# Altium schematic sheet DVD_adapter.SchDoc
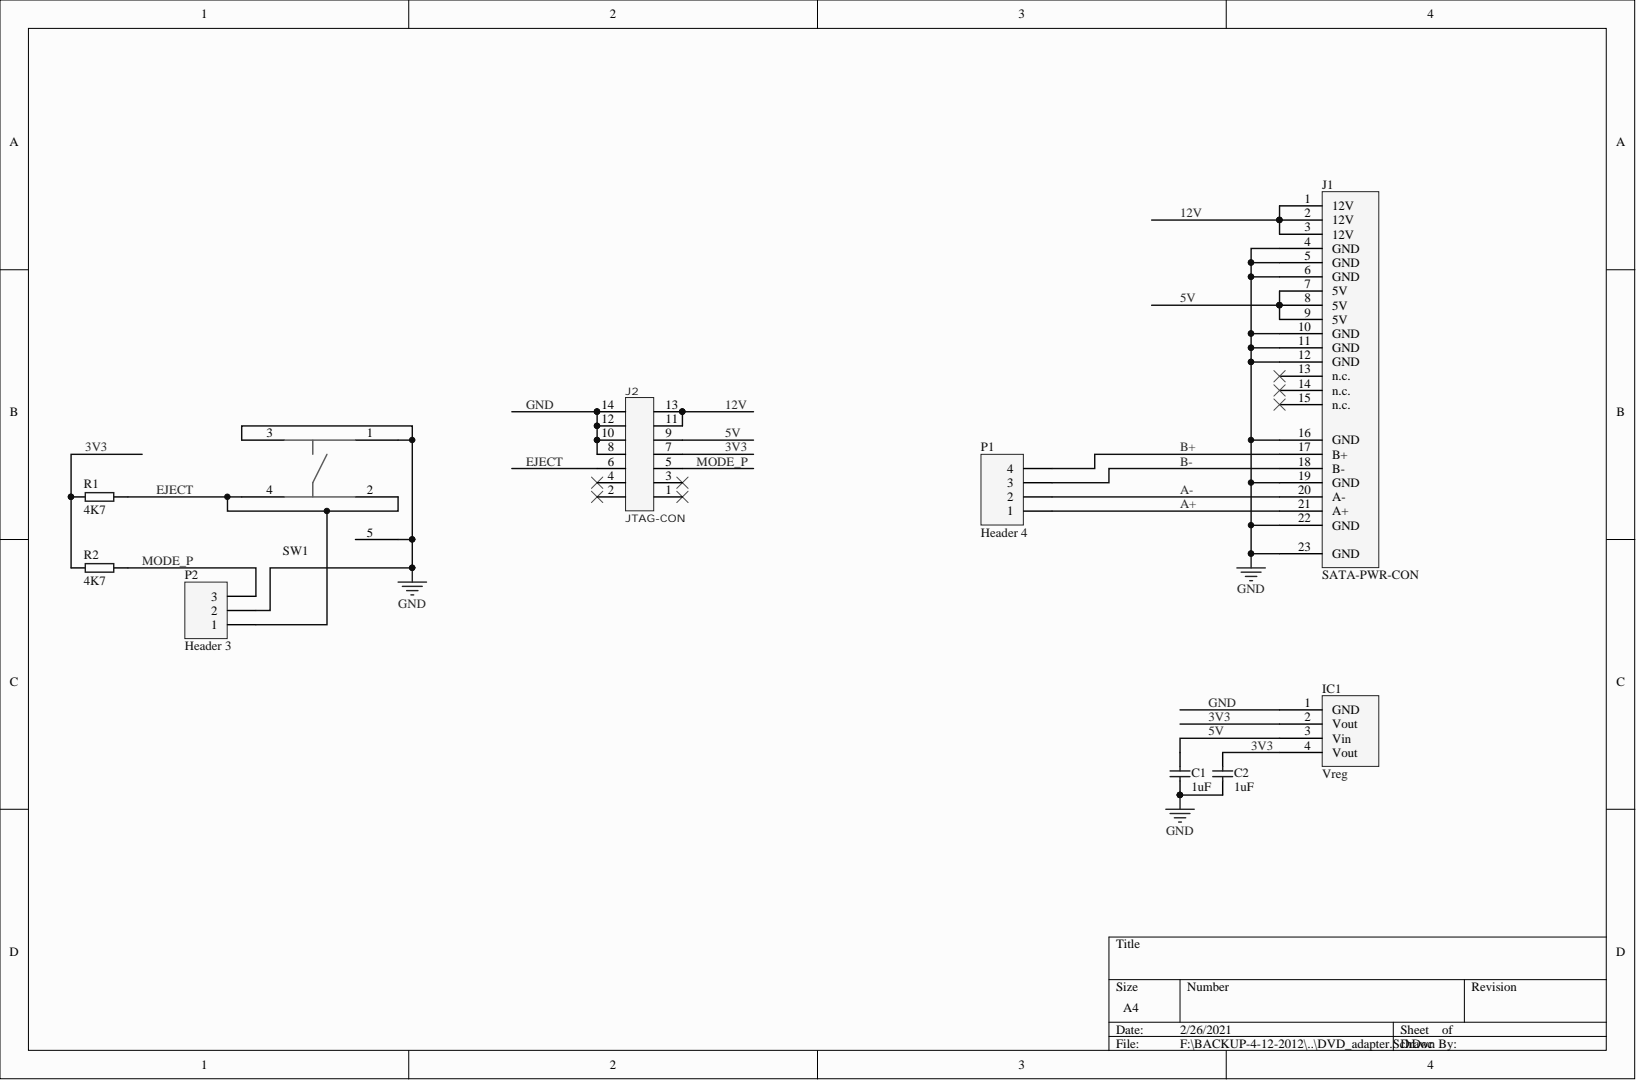
[diagram p0: DVD_adapter.SchDoc]

## Parts
C1  value 1uF  [CAPC1608N]  (hidden comment: CAP-NP)
C2  value 1uF  [CAPC1608N]  (hidden comment: CAP-NP)
IC1  Vreg  [SOT223]
J1  SATA-PWR-CON  [SATA-PWR-CON]
J2  JTAG-CON  [JTAG-CON]
P1  Header 4  [HDR1X4]
P2  Header 3  [HDR1X3]
R1  value 4K7  [RESC2012N]  (hidden comment: RES)
R2  value 4K7  [RESC2012N]  (hidden comment: RES)
SW1  KMR2  [KMR2]  (value not drawn)

## Nets
3V3: C2.2 IC1.2(Vout) IC1.4(Vout) J2.7 R1.1 R2.1
5V: C1.2 IC1.3(Vin) J1.7(5V) J1.8(5V) J1.9(5V) J2.9
12V: J1.1(12V) J1.2(12V) J1.3(12V) J2.11 J2.13
A+: J1.21(A+) P1.1
A-: J1.20(A-) P1.2
B+: J1.17(B+) P1.4
B-: J1.18(B-) P1.3
EJECT: J2.6 P2.1 R1.2 SW1.2 SW1.4
GND: C1.1 C2.1 IC1.1(GND) J1.4(GND) J1.5(GND) J1.6(GND) J1.10(GND) J1.11(GND) J1.12(GND) J1.16(GND) J1.19(GND) J1.22(GND) J1.23(GND) J2.8 J2.10 J2.12 J2.14 P2.2 SW1.1 SW1.3 SW1.5
MODE_P: J2.5 P2.3 R2.2

Not connected: J1 13(n.c.) 14(n.c.) 15(n.c.); J2 1 2 3 4

## Library data of the parts
C1 C2 = 1uF: Database Table Name: C; Component Kind: Standard; Library Name: trioflex.DbLib
IC1 = Vreg: Database Table Name: IC; ID: 27; Component: Vreg; Component Kind: Standard; Description: Vreg; Value: Vreg; Library Name: trioflex.DbLib
J1 = SATA-PWR-CON: Database Table Name: CONN; ID: 34; Component: SATA-PWR-CON; Component Kind: Standard; Description: SATA-PWR-CON; Value: SATA-PWR-CON; Library Name: trioflex.DbLib
J2 = JTAG-CON: Database Table Name: CONN; ID: 35; Component Kind: Standard; Value: JTAG-CON; Library Name: trioflex.DbLib
P1 = Header 4: Header, 4-Pin; Component Kind: Standard; LatestRevisionDate: 17-Jul-2002; LatestRevisionNote: Re-released for DXP Platform.; Library Name: Miscellaneous Connectors.IntLib; Signal Integrity: Connector; Publisher: Altium Limited
P2 = Header 3: Header, 3-Pin; Component Kind: Standard; LatestRevisionDate: 17-Jul-2002; LatestRevisionNote: Re-released for DXP Platform.; Library Name: Miscellaneous Connectors.IntLib; Signal Integrity: Connector; Publisher: Altium Limited
R1 R2 = R0805: Database Table Name: R; ID: 8; Component: R0805; Component Kind: Standard; Library Name: trioflex.DbLib
SW1 = KMR2: KMR2 Tactile Switch; Component: PB-KMR2; ID: 3; Component Kind: Standard; Database Table Name: SWITCH; Value: KMR2; Library Name: trioflex.DbLib
